# Altium schematic sheet HDMI.SchDoc
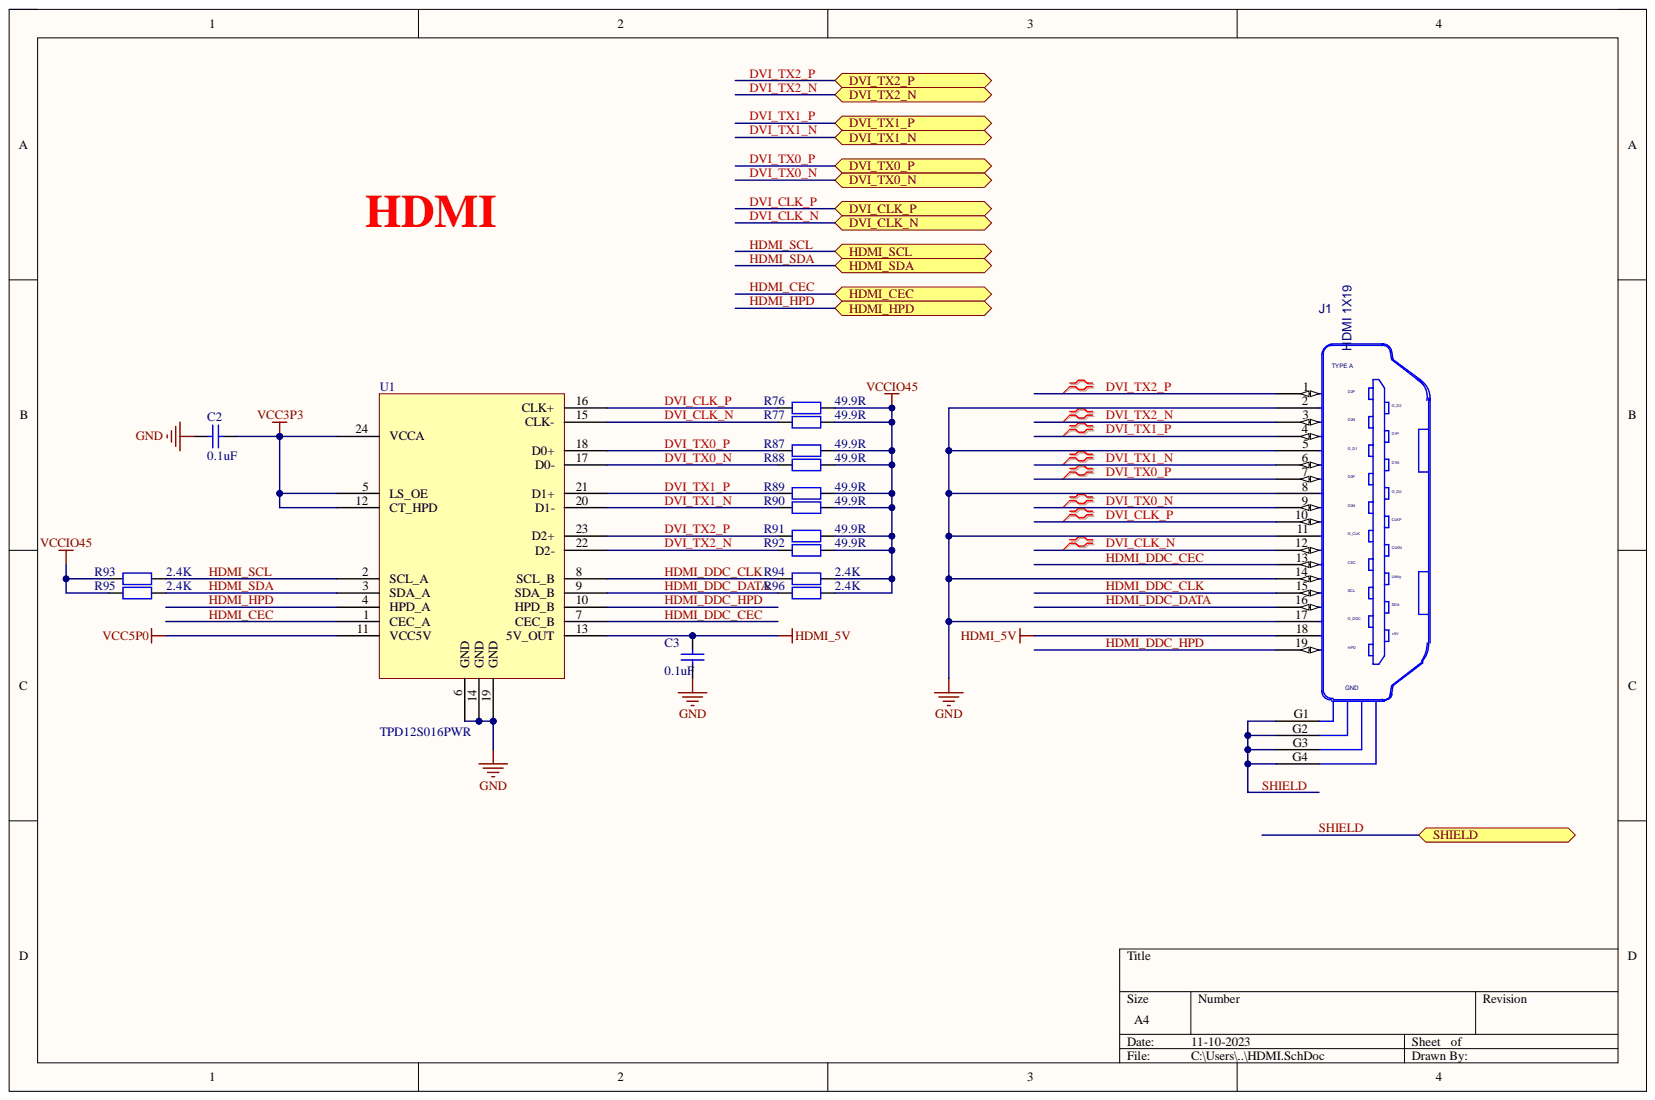
[diagram p0: HDMI.SchDoc]

## Parts
C2  0.1uF  [C0402]
C3  0.1uF  [C0402]
J1  HDMI 1X19  [HDMI_A]
R76  49.9R  [R0402]
R77  49.9R  [R0402]
R87  49.9R  [R0402]
R88  49.9R  [R0402]
R89  49.9R  [R0402]
R90  49.9R  [R0402]
R91  49.9R  [R0402]
R92  49.9R  [R0402]
R93  2.4K  [R0402]
R94  2.4K  [R0402]
R95  2.4K  [R0402]
R96  2.4K  [R0402]
U1  TPD12S016PWR  [TSSOP-24_L7_8W4_4P0_65]

## Ports
DVI_CLK_N, DVI_CLK_P, DVI_TX0_N, DVI_TX0_P, DVI_TX1_N, DVI_TX1_P, DVI_TX2_N, DVI_TX2_P, HDMI_CEC, HDMI_HPD, HDMI_SCL, HDMI_SDA, SHIELD

## Nets
GND: C2.2 C3.2 J1.2 J1.5 J1.8 J1.11 J1.14 J1.17 U1.6(GND) U1.14(GND) U1.19(GND)
HDMI_5V: C3.1 J1.18 U1.13(5V_OUT)
VCC3P3: C2.1 U1.5(LS_OE) U1.12(CT_HPD) U1.24(VCCA)
VCC5P0: U1.11(VCC5V)
VCCIO45: R76.1 R77.1 R87.1 R88.1 R89.1 R90.1 R91.1 R92.1 R93.2 R94.1 R95.2 R96.1
DVI_CLK_N: J1.12 R77.2 U1.15(CLK-) ; port DVI_CLK_N
DVI_CLK_P: J1.10 R76.2 U1.16(CLK+) ; port DVI_CLK_P
DVI_TX0_N: J1.9 R88.2 U1.17(D0-) ; port DVI_TX0_N
DVI_TX0_P: J1.7 R87.2 U1.18(D0+) ; port DVI_TX0_P
DVI_TX1_N: J1.6 R90.2 U1.20(D1-) ; port DVI_TX1_N
DVI_TX1_P: J1.4 R89.2 U1.21(D1+) ; port DVI_TX1_P
DVI_TX2_N: J1.3 R92.2 U1.22(D2-) ; port DVI_TX2_N
DVI_TX2_P: J1.1 R91.2 U1.23(D2+) ; port DVI_TX2_P
HDMI_CEC: U1.1(CEC_A) ; port HDMI_CEC
HDMI_DDC_CEC: J1.13 U1.7(CEC_B)
HDMI_DDC_CLK: J1.15 R94.2 U1.8(SCL_B)
HDMI_DDC_DATA: J1.16 R96.2 U1.9(SDA_B)
HDMI_DDC_HPD: J1.19 U1.10(HPD_B)
HDMI_HPD: U1.4(HPD_A) ; port HDMI_HPD
HDMI_SCL: R93.1 U1.2(SCL_A) ; port HDMI_SCL
HDMI_SDA: R95.1 U1.3(SDA_A) ; port HDMI_SDA
SHIELD: J1.G1 J1.G2 J1.G3 J1.G4 ; port SHIELD

## Library data of the parts
C2 C3 = Cap: Capacitor; Component Kind: Standard; LatestRevisionDate: 17-Jul-2002; LatestRevisionNote: Re-released for DXP Platform.; PackageReference: RAD-0.3; Published: 8-Jun-2000; Signal Integrity: Cap; Simulation: CAP; Value: 0.1uF; Library Name: 元件库封装.pcblib; Publisher: Altium Limited
J1 = ATOM010130010052_HDMI_1: CON 1X19 HDMI SMT 0.5MM 249H AU --; AGILE_PN: 211-79674; CAD_APPROVED: Yes; CLASS: IO; MFG_NAME01: SHENZHEN ATOM TECHNOLOGY CO.,LTD; MFG_PN01: HD119F-3A04202; OriginalSymbolOrigin: (-200,650); Vendor: Comtek; VPN: 1166-2C105-211; Component Kind: Standard; HEIGHT: 6.33MM; Library Name: zynq.SCHLIB; ROHS: Yes
R76 R77 R87-R96 = Res2: Resistor; Component Kind: Standard; LatestRevisionDate: 17-Jul-2002; LatestRevisionNote: Re-released for DXP Platform.; PackageReference: AXIAL-0.4; Published: 8-Jun-2000; Library Name: 元件封装库.pcblib; Publisher: Altium Limited
  R76 R77 R87-R92: Value: 49.9R
  R93-R96: Value: 2.4K
U1 = TPD12S016PWR: Component Kind: Standard; Description: TPD12S016PWR; Library Name: Gowin.SchLib
